# Altium schematic sheet Power.SchDoc
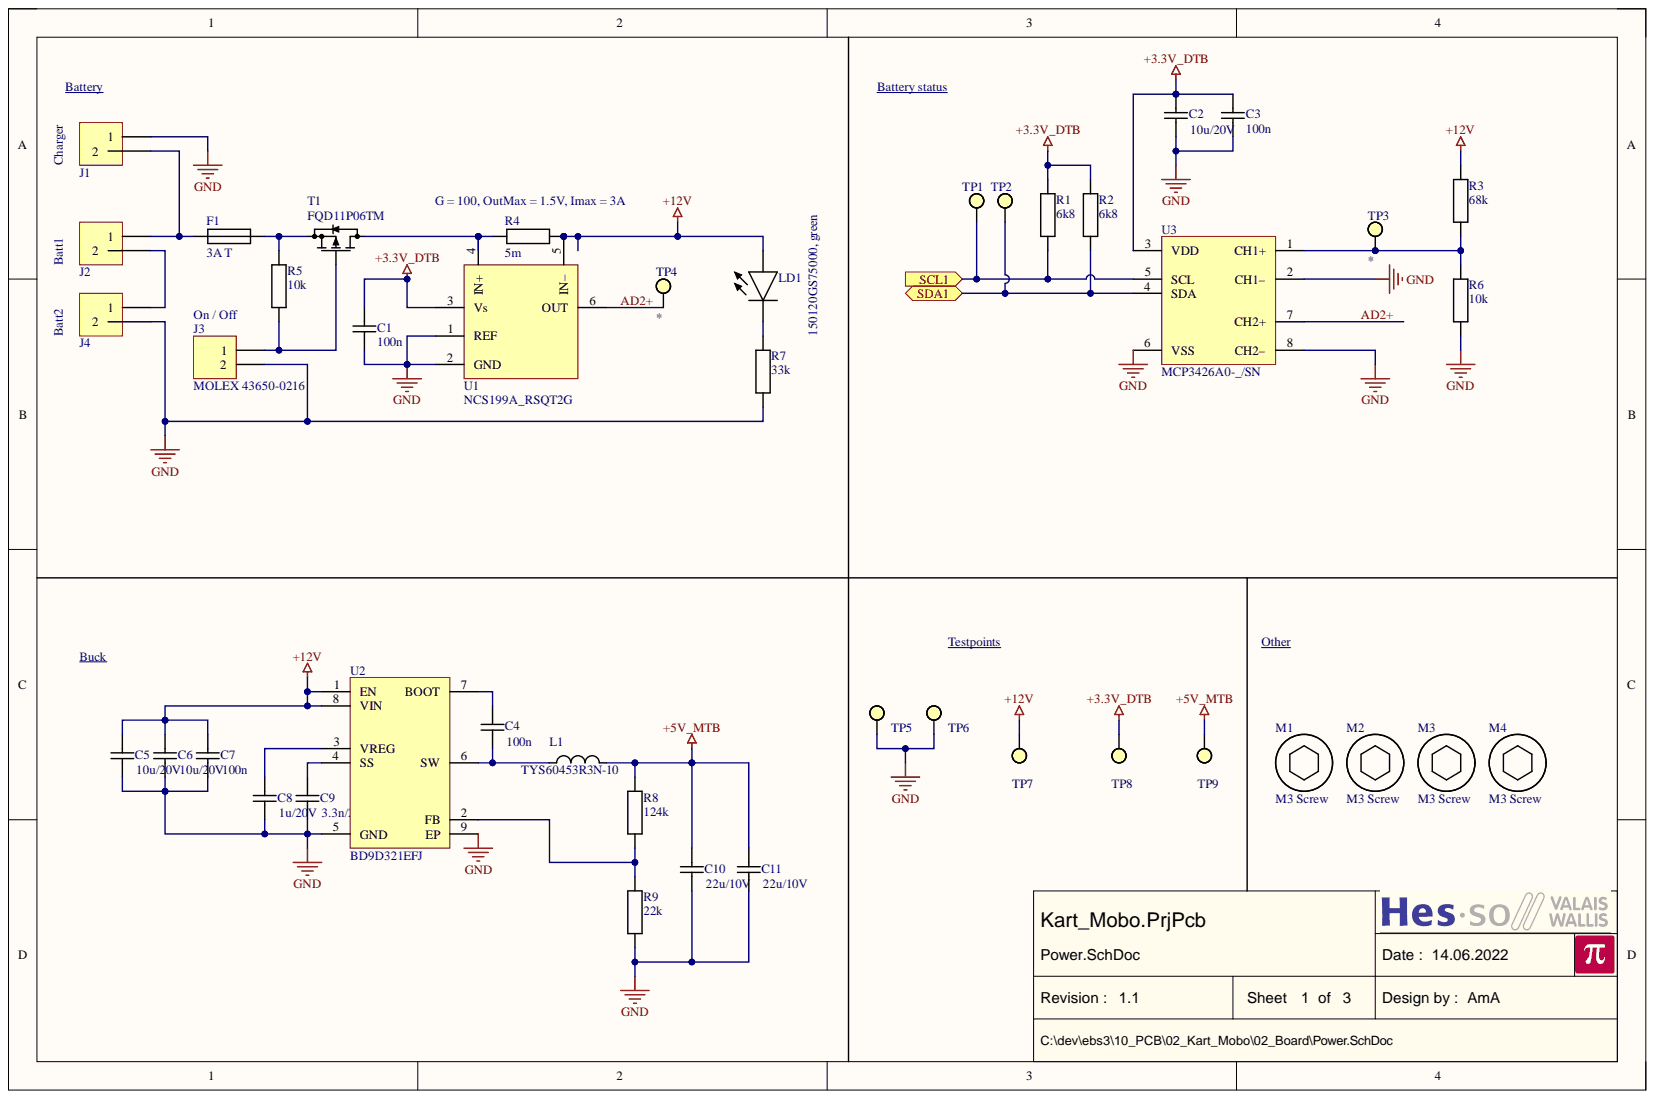
[diagram p0: Power.SchDoc]

## Parts
C1  100n  [CAPACITOR 1608 REFLOW]
C2  10u/20V  [CAPACITOR 2012 REFLOW]
C3  100n  [CAPACITOR 1608 REFLOW]
C4  100n  [CAPACITOR 1608 REFLOW]
C5  10u/20V  [CAPACITOR 2012 REFLOW]
C6  10u/20V  [CAPACITOR 2012 REFLOW]
C7  100n  [CAPACITOR 1608 REFLOW]
C8  1u/20V  [CAPACITOR 2012 REFLOW]
C9  3.3n/20V  [CAPACITOR 2012 REFLOW]
C10  22u/10V  [CAPACITOR 2012 REFLOW]
C11  22u/10V  [CAPACITOR 2012 REFLOW]
F1  3A T  [LittleFuse series 0154 C10X5.0 H3.8]
J1  (no value)  [MOLEX 39-28-8020]
J2  (no value)  [MOLEX 39-28-8020]
J3  MOLEX 43650-0216  [MOLEX 43650-0216]
J4  (no value)  [MOLEX 39-28-8020]
L1  TYS60453R3N-10  [TAIYO YUDEN NR6028T]
LD1  150120GS75000, green  [LED 3216 REFLOW]
M1  M3 Screw  [Screw Hex Socket M3X5 Unconnected]
M2  M3 Screw  [Screw Hex Socket M3X5 Unconnected]
M3  M3 Screw  [Screw Hex Socket M3X5 Unconnected]
M4  M3 Screw  [Screw Hex Socket M3X5 Unconnected]
R1  6k8  [RESISTOR 1608 REFLOW]
R2  6k8  [RESISTOR 1608 REFLOW]
R3  68k  [RESISTOR 1608 REFLOW]
R4  5m  [RESISTOR 3216 REFLOW]
R5  10k  [RESISTOR 1608 REFLOW]
R6  10k  [RESISTOR 1608 REFLOW]
R7  33k  [RESISTOR 1608 REFLOW]
R8  124k  [RESISTOR 1608 REFLOW]
R9  22k  [RESISTOR 1608 REFLOW]
T1  FQD11P06TM  [TO252AA-2 DPAK P2.29 C6.2x6.7 H2.4]
TP1  (no value)  [Test Point ARWIN S2761-46R]
TP2  (no value)  [Test Point ARWIN S2761-46R]
TP3  (no value)  [Pad D1.5]  (no BOM)
TP4  (no value)  [Pad D1.5]  (no BOM)
TP5  (no value)  [Test Point ARWIN S2761-46R]
TP6  (no value)  [Test Point ARWIN S2761-46R]
TP7  S2761-46R  [Test Point ARWIN S2761-46R]  (value not drawn)
TP8  (no value)  [Test Point ARWIN S2761-46R]
TP9  (no value)  [Test Point ARWIN S2761-46R]
U1  NCS199A_RSQT2G  [SC70-6 P0.65 C1.4x2.2 H1.0]
U2  BD9D321EFJ  [BD9D321EFJ]
U3  MCP3426A0-_/SN  [SO8 P1.27 C4.0X5.0 H1.75]

## Ports
SCL1, SDA1

## Nets
+3.3V_DTB: C1.2 C2.2 C3.2 R1.2 R2.2 TP8.1 U1.3(Vs) U3.3(VDD)
+5V_MTB: C10.2 C11.2 L1.2 R8.2 TP9.1
+12V: C5.2 C6.2 C7.2 LD1.2 R3.2 R4.1 TP7.1 U1.5(IN–) U2.1(EN) U2.8(VIN)
GND: C1.1 C2.1 C3.1 C5.1 C6.1 C7.1 C8.1 C9.1 C10.1 C11.1 J1.1 J3.2 J4.2 R6.1 R7.1 R9.1 TP5.1 TP6.1 U1.1(REF) U1.2(GND) U2.5(GND) U2.9(EP) U3.2(CH1–) U3.6(VSS) U3.8(CH2–)
AD2+: TP4.1 U1.6(OUT) U3.7(CH2+)
SCL1: R1.1 TP1.1 U3.5(SCL) ; port SCL1
SDA1: R2.1 TP2.1 U3.4(SDA) ; port SDA1
NetC4_1: C4.1 L1.1 U2.6(SW)
NetC4_2: C4.2 U2.7(BOOT)
NetC8_2: C8.2 U2.3(VREG)
NetC9_2: C9.2 U2.4(SS)
NetF1_1: F1.1 J1.2 J2.1
NetF1_2: F1.2 R5.2 T1.3
NetJ2_2: J2.2 J4.1
NetJ3_1: J3.1 R5.1 T1.1
NetLD1_1: LD1.1 R7.2
NetR3_1: R3.1 R6.2 TP3.1 U3.1(CH1+)
NetR4_2: R4.2 T1.2 U1.4(IN+)
NetR8_1: R8.1 R9.2 U2.2(FB)

## Library data of the parts
C1-C11 = Capacitor: Component Kind: Standard; Description: Capacitor; Library Name: Capacitor.IntLib
  C1 C3 C4 C7: Value: 100n
  C2 C5 C6: Value: 10u/20V
  C8: Value: 1u/20V
  C9: Value: 3.3n/20V
  C10 C11: Value: 22u/10V
F1 = LittleFuse series 0154: LittleFuse series 0154 C10X5.0 H3.8; Component Kind: Standard; Library Name: Fuse.IntLib; Value: 3A T
J1 J2 J4 = MOLEX 39-28-8020: Mini-Fit Jr. Header, Vertical, 2 Circuits; Component Kind: Standard; Library Name: Connector.IntLib
J3 = MOLEX 43650-0216: Micro-Fit 3.0 Vertical Header, 3.00mm Pitch, Single Row, 2 Circuits; Component Kind: Standard; Library Name: Connector.IntLib
L1 = Inductor: Component Kind: Standard; Description: Inductor; Library Name: Inductor.IntLib; Value: TYS60453R3N-10
LD1 = LED: LED generic; Component Kind: Standard; Library Name: Diode.IntLib; Value: 150120GS75000, green
M1-M4 = Screw Hex Socket Unconnected: Screw Hex Socket Unconnected BN610; Component Kind: Standard; Library Name: Mechanic.IntLib; Value: M3 Screw
R1-R9 = Resistor: Component Kind: Standard; Description: Resistor; Library Name: Resistor.IntLib
  R1 R2: Value: 6k8
  R3: Value: 68k
  R4: Value: 5m
  R5 R6: Value: 10k
  R7: Value: 33k
  R8: Value: 124k
  R9: Value: 22k
T1 = MOS-P TO252 G-D-S: Generic MOS-P; Component Kind: Standard; Library Name: Transistor.IntLib; Value: FQD11P06TM
TP1 TP2 TP5-TP9 = Test Point: Component Kind: Standard; Description: Test Point; Library Name: Testpoint.IntLib
  TP7: Value: S2761-46R
TP3 TP4 = Pad: Description: Pad; Library Name: Testpoint.IntLib
U1 = NCS199A_RSQT2G: NCS199A1R; Component Kind: Standard; Library Name: IntegratedCircuit.IntLib; Value: NCS199A_RSQT2G
U2 = BD9D321EFJ: Integrated MOSFET Buck DC/DC Converter; Component Kind: Standard; Library Name: VoltageConverter.IntLib; Value: BD9D321EFJ
U3 = MCP3426A0-_/SN: 16-Bit, Multi-Channel ΔΣ Analog-to-Digital Converter; Component Kind: Standard; Library Name: Converter.IntLib; Value: MCP3426A0-_/SN
